# Altium schematic sheet lv_io_pressure.SchDoc
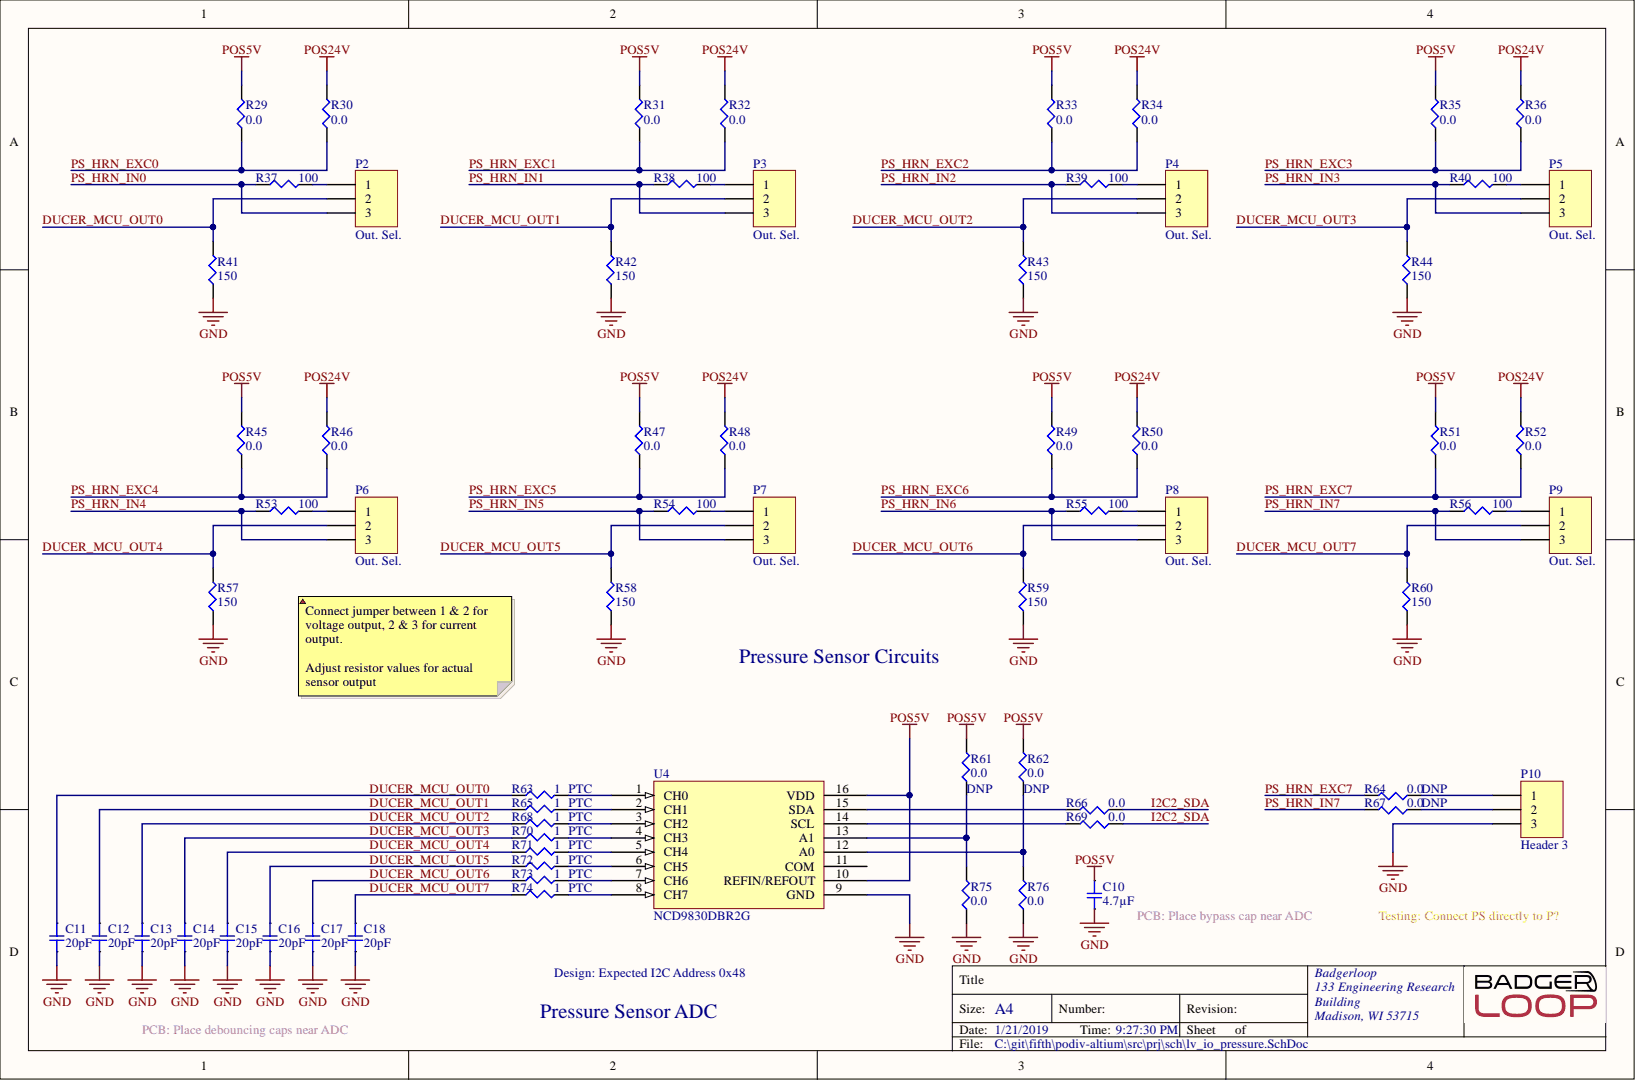
[diagram p0: lv_io_pressure.SchDoc]

## Parts
C10  4.7µF  [SMD-0805C]
C11  20pF  [SMD-0402C]
C12  20pF  [SMD-0402C]
C13  20pF  [SMD-0402C]
C14  20pF  [SMD-0402C]
C15  20pF  [SMD-0402C]
C16  20pF  [SMD-0402C]
C17  20pF  [SMD-0402C]
C18  20pF  [SMD-0402C]
P2  Out. Sel.  [Pin Header 1x3 TH Pitch 2.54mm]
P3  Out. Sel.  [Pin Header 1x3 TH Pitch 2.54mm]
P4  Out. Sel.  [Pin Header 1x3 TH Pitch 2.54mm]
P5  Out. Sel.  [Pin Header 1x3 TH Pitch 2.54mm]
P6  Out. Sel.  [Pin Header 1x3 TH Pitch 2.54mm]
P7  Out. Sel.  [Pin Header 1x3 TH Pitch 2.54mm]
P8  Out. Sel.  [Pin Header 1x3 TH Pitch 2.54mm]
P9  Out. Sel.  [Pin Header 1x3 TH Pitch 2.54mm]
P10  Header 3  [Pin Header 1x3 TH Pitch 2.54mm]
R29  0.0  [SMD-0402-RES]
R30  0.0  [SMD-0402-RES]
R31  0.0  [SMD-0402-RES]
R32  0.0  [SMD-0402-RES]
R33  0.0  [SMD-0402-RES]
R34  0.0  [SMD-0402-RES]
R35  0.0  [SMD-0402-RES]
R36  0.0  [SMD-0402-RES]
R37  100  [SMD-0402-RES]
R38  100  [SMD-0402-RES]
R39  100  [SMD-0402-RES]
R40  100  [SMD-0402-RES]
R41  150  [SMD-0603-RES]
R42  150  [SMD-0603-RES]
R43  150  [SMD-0603-RES]
R44  150  [SMD-0603-RES]
R45  0.0  [SMD-0402-RES]
R46  0.0  [SMD-0402-RES]
R47  0.0  [SMD-0402-RES]
R48  0.0  [SMD-0402-RES]
R49  0.0  [SMD-0402-RES]
R50  0.0  [SMD-0402-RES]
R51  0.0  [SMD-0402-RES]
R52  0.0  [SMD-0402-RES]
R53  100  [SMD-0402-RES]
R54  100  [SMD-0402-RES]
R55  100  [SMD-0402-RES]
R56  100  [SMD-0402-RES]
R57  150  [SMD-0603-RES]
R58  150  [SMD-0603-RES]
R59  150  [SMD-0603-RES]
R60  150  [SMD-0603-RES]
R61  0.0  [SMD-0402-RES]
R62  0.0  [SMD-0402-RES]
R63  1  Features: PTC  [SMD-0603-RES]
R64  0.0  [SMD-0402-RES]
R65  1  Features: PTC  [SMD-0603-RES]
R66  0.0  [SMD-0402-RES]
R67  0.0  [SMD-0402-RES]
R68  1  Features: PTC  [SMD-0603-RES]
R69  0.0  [SMD-0402-RES]
R70  1  Features: PTC  [SMD-0603-RES]
R71  1  Features: PTC  [SMD-0603-RES]
R72  1  Features: PTC  [SMD-0603-RES]
R73  1  Features: PTC  [SMD-0603-RES]
R74  1  Features: PTC  [SMD-0603-RES]
R75  0.0  [SMD-0402-RES]
R76  0.0  [SMD-0402-RES]
U4  NCD9830DBR2G  [TSOP65P640X120_HS-17N]

## Nets
GND: C10.2 C11.2 C12.2 C13.2 C14.2 C15.2 C16.2 C17.2 C18.2 P10.3 R41.1 R42.1 R43.1 R44.1 R57.1 R58.1 R59.1 R60.1 R75.1 R76.1 U4.9(GND)
POS5V: C10.1 R29.2 R31.2 R33.2 R35.2 R45.2 R47.2 R49.2 R51.2 R61.2 R62.2 U4.10(REFIN/REFOUT) U4.16(VDD)
POS24V: R30.2 R32.2 R34.2 R36.2 R46.2 R48.2 R50.2 R52.2
DUCER_MCU_OUT0: C11.1 P2.2 R41.2 R63.1
DUCER_MCU_OUT1: C12.1 P3.2 R42.2 R65.1
DUCER_MCU_OUT2: C13.1 P4.2 R43.2 R68.1
DUCER_MCU_OUT3: C14.1 P5.2 R44.2 R70.1
DUCER_MCU_OUT4: C15.1 P6.2 R57.2 R71.1
DUCER_MCU_OUT5: C16.1 P7.2 R58.2 R72.1
DUCER_MCU_OUT6: C17.1 P8.2 R59.2 R73.1
DUCER_MCU_OUT7: C18.1 P9.2 R60.2 R74.1
I2C2_SDA: R66.1 R69.1
PS_HRN_EXC0: R29.1 R30.1
PS_HRN_EXC1: R31.1 R32.1
PS_HRN_EXC2: R33.1 R34.1
PS_HRN_EXC3: R35.1 R36.1
PS_HRN_EXC4: R45.1 R46.1
PS_HRN_EXC5: R47.1 R48.1
PS_HRN_EXC6: R49.1 R50.1
PS_HRN_EXC7: R51.1 R52.1 R64.2
PS_HRN_IN0: P2.3 R37.1
PS_HRN_IN1: P3.3 R38.1
PS_HRN_IN2: P4.3 R39.1
PS_HRN_IN3: P5.3 R40.1
PS_HRN_IN4: P6.3 R53.1
PS_HRN_IN5: P7.3 R54.1
PS_HRN_IN6: P8.3 R55.1
PS_HRN_IN7: P9.3 R56.1 R67.2
NetP2_1: P2.1 R37.2
NetP3_1: P3.1 R38.2
NetP4_1: P4.1 R39.2
NetP5_1: P5.1 R40.2
NetP6_1: P6.1 R53.2
NetP7_1: P7.1 R54.2
NetP8_1: P8.1 R55.2
NetP9_1: P9.1 R56.2
NetP10_1: P10.1 R64.1
NetP10_2: P10.2 R67.1
NetR61_1: R61.1 R75.2 U4.13(A1)
NetR62_1: R62.1 R76.2 U4.12(A0)
NetR63_2: R63.2 U4.1(CH0)
NetR65_2: R65.2 U4.2(CH1)
NetR66_2: R66.2 U4.15(SDA)
NetR68_2: R68.2 U4.3(CH2)
NetR69_2: R69.2 U4.14(SCL)
NetR70_2: R70.2 U4.4(CH3)
NetR71_2: R71.2 U4.5(CH4)
NetR72_2: R72.2 U4.6(CH5)
NetR73_2: R73.2 U4.7(CH6)
NetR74_2: R74.2 U4.8(CH7)

Not connected: U4 11(COM)

## Library data of the parts
C10 = GRM21BR60J475KA11L: CAP CER 4.7UF 6.3V X5R 0805; Manufacturer: Murata Electronics North America; @ qty: 0; Minimum Quantity: 1; Tolerance: ±10%; Unit Price (USD): 0.28; Applications: General Purpose; Component Kind: Standard; Datasheets: http://www.murata.com/~/media/webrenewal/support/library/catalog/products/capacitor/mlcc/c02e.pdf; Digi-Key Part Number: 490-9749-1-ND; Factory Stock: 0; Features: -; Height - Seated (Max): -; Image: //media.digikey.com/Renders/Murata%20Renders/0805%20(2012%20Metric)%20.85mm.jpg; Lead Spacing: -; Lead Style: -; Library Name: capacitor.SchLib; Mounting Type: Surface Mount, MLCC; Operating Temperature: -55°C ~ 85°C; Package / Case: 0805 (2012 Metric); Packaging: Cut Tape (CT); Part Number: GRM21BR60J475KA11L; Part Status: Active; Quantity Available: 2821; Ratings: -; Series: GRM; Size / Dimension: 0.079" L x 0.049" W (2.00mm x 1.25mm); Temperature Coefficient: X5R; Thickness (Max): 0.055" (1.40mm); Value: 4.7µF; Voltage: 6.3V
C11-C18 = GJM1555C1H200JB01D: CAP CER 20PF 50V NP0 0402; Manufacturer: Murata Electronics North America; @ qty: 0; Minimum Quantity: 1; Temperature Coefficient: C0G, NP0; Tolerance: ±5%; Unit Price (USD): 0.1; Applications: RF, Microwave, High Frequency; Component Kind: Standard; Datasheets: http://www.murata.com/~/media/webrenewal/support/library/catalog/products/capacitor/mlcc/c02e.pdf; Digi-Key Part Number: 490-3120-1-ND; Factory Stock: 0; Features: High Q, Low Loss; Height - Seated (Max): -; Image: //media.digikey.com/Renders/Murata%20Renders/ZRB-SERIES-0402.jpg; Lead Spacing: -; Lead Style: -; Library Name: capacitor.SchLib; Mounting Type: Surface Mount, MLCC; Operating Temperature: -55°C ~ 125°C; Package / Case: 0402 (1005 Metric); Packaging: Cut Tape (CT); Part Number: GJM1555C1H200JB01D; Part Status: Active; Quantity Available: 13133; Ratings: -; Series: GJM; Size / Dimension: 0.039" L x 0.020" W (1.00mm x 0.50mm); Thickness (Max): 0.022" (0.55mm); Value: 20pF; Voltage: 50V
P2-P10 = Header 3: Header, 3-Pin; Component Kind: Standard; LatestRevisionDate: 17-Jul-2002; LatestRevisionNote: Re-released for DXP Platform.; Library Name: connector.SchLib; Signal Integrity: Connector; Publisher: Altium Limited
R29-R36 R45-R52 R61 R62 R64 R66 R67 R69 R75 R76 = ERJ-2GE0R00X: RES SMD 0.0OHM JUMPER 1/10W 0402; Manufacturer: Panasonic Electronic Components; @ qty: 1500; Factory Stock: 0; Minimum Quantity: 1; Power (Watts): 0.1W, 1/10W; Unit Price (USD): 0.00384; Component Kind: Standard; Composition: Thick Film; Datasheets: http://industrial.panasonic.com/www-cgi/jvcr13pz.cgi?E+PZ+3+AOA0001+ERJ2GE0R00X+7+WW; Digi-Key Part Number: P0.0JCT-ND; Failure Rate: -; Features: Automotive AEC-Q200; Height - Seated (Max): 0.016" (0.40mm); Image: //media.digikey.com/Photos/Panasonic%20Photos/ERJ-PA2-Series.jpg; Library Name: resistor.SchLib; Number of Terminations: 2; Operating Temperature: -55°C ~ 155°C; Package / Case: 0402 (1005 Metric); Packaging: Cut Tape (CT); Part Number: ERJ-2GE0R00X; Part Status: Active; Quantity Available: 3152483; Series: ERJ; Size / Dimension: 0.039" L x 0.020" W (1.00mm x 0.50mm); Supplier Device Package: 0402; Temperature Coefficient: -; Tolerance: Jumper; Value: 0.0
  R61 R62 R64 R67: DNP: DNP
R37-R40 R53-R56 = ERJ-2GEJ101X: RES SMD 100 OHM 5% 1/10W 0402; Manufacturer: Panasonic Electronic Components; @ qty: 1500; Factory Stock: 0; Minimum Quantity: 1; Power (Watts): 0.1W, 1/10W; Temperature Coefficient: ±200ppm/°C; Unit Price (USD): 0.00350; Component Kind: Standard; Composition: Thick Film; Datasheets: http://industrial.panasonic.com/www-cgi/jvcr13pz.cgi?E+PZ+3+AOA0001+ERJ2GEJ101X+7+WW; Digi-Key Part Number: P100JCT-ND; Failure Rate: -; Features: Automotive AEC-Q200; Height - Seated (Max): 0.016" (0.40mm); Image: //media.digikey.com/Photos/Panasonic%20Photos/ERJ-PA2-Series.jpg; Library Name: resistor.SchLib; Number of Terminations: 2; Operating Temperature: -55°C ~ 155°C; Package / Case: 0402 (1005 Metric); Packaging: Cut Tape (CT); Part Number: ERJ-2GEJ101X; Part Status: Active; Quantity Available: 3114113; Series: ERJ; Size / Dimension: 0.039" L x 0.020" W (1.00mm x 0.50mm); Supplier Device Package: 0402; Tolerance: ±5%; Value: 100
R41-R44 R57-R60 = ERJ-P03D1500V: RES SMD 150 OHM 0.5% 1/5W 0603; Manufacturer: Panasonic Electronic Components; @ qty: 1500; Factory Stock: 0; Minimum Quantity: 1; Power (Watts): 0.2W, 1/5W; Temperature Coefficient: ±150ppm/°C; Unit Price (USD): 0.06582; Component Kind: Standard; Composition: Thick Film; Datasheets: https://industrial.panasonic.com/cdbs/www-data/pdf/RDA0000/AOA0000C243.pdf; Digi-Key Part Number: P16013CT-ND; Failure Rate: -; Features: Automotive AEC-Q200, Pulse Withstanding; Height - Seated (Max): 0.022" (0.55mm); Image: //media.digikey.com/Photos/Panasonic%20Photos/MFG_ERJ-P6W%20Series.jpg; Library Name: resistor.SchLib; Number of Terminations: 2; Operating Temperature: -55°C ~ 155°C; Package / Case: 0603 (1608 Metric); Packaging: Cut Tape (CT); Part Number: ERJ-P03D1500V; Part Status: Active; Quantity Available: 4150; Series: ERJ; Size / Dimension: 0.063" L x 0.031" W (1.60mm x 0.80mm); Supplier Device Package: 0603; Tolerance: ±0.5%; Value: 150
R63 R65 R68 R70-R74 = PRG18BB470MB1RB: PTC RESET FUSE 6V 330MA 0603; Manufacturer: Murata; Manufacturer P/N: PRG18BC1R0MM1RB; @ qty: 1500; Factory Stock: 0; Power (Watts): 0.167W, 1/6W; Temperature Coefficient: ±250ppm/°C; Tolerance: ±1%; Unit Price (USD): 0.10080; Component Kind: Standard; Composition: Thick Film; Digi-Key Part Number: 490-8505-1-ND; Digikey Link: https://www.digikey.com/product-detail/en/murata-electronics-north-america/PRG18BC1R0MM1RB/490-8505-1-ND/4380768; Failure Rate: -; Height - Seated (Max): 0.016" (0.40mm); Image: //media.digikey.com/Photos/Panasonic%20Photos/ERJ-PA2-Series.jpg; Library Name: temp.SchLib; Minimum Quantity: 1; Number of Terminations: 2; Operating Temperature: -55°C ~ 125°C; Package / Case: 0603; Packaging: Cut Tape (CT); Part Status: Active; Quantity Available: 14922; Size / Dimension: 0.039" L x 0.020" W (1.00mm x 0.50mm); Supplier Device Package: 0402; Value: 1
U4 = NCD9830DBR2G: IC ADC 8BIT 8CH I2C 16TSSOP; Manufacturer: ON Semiconductor; Component Kind: Standard; Cost: 2.70; Digikey Link: https://www.digikey.com/product-detail/en/on-semiconductor/NCD9830DBR2G/NCD9830DBR2GOSCT-ND/9086945; Digikey P/N: NCD9830DBR2GOSCT-ND; Library Name: ic.SchLib; Manufacturer P/N: NCD9830DBR2G
